# Altium schematic sheet 2_POWER.SchDoc(2_POWER)
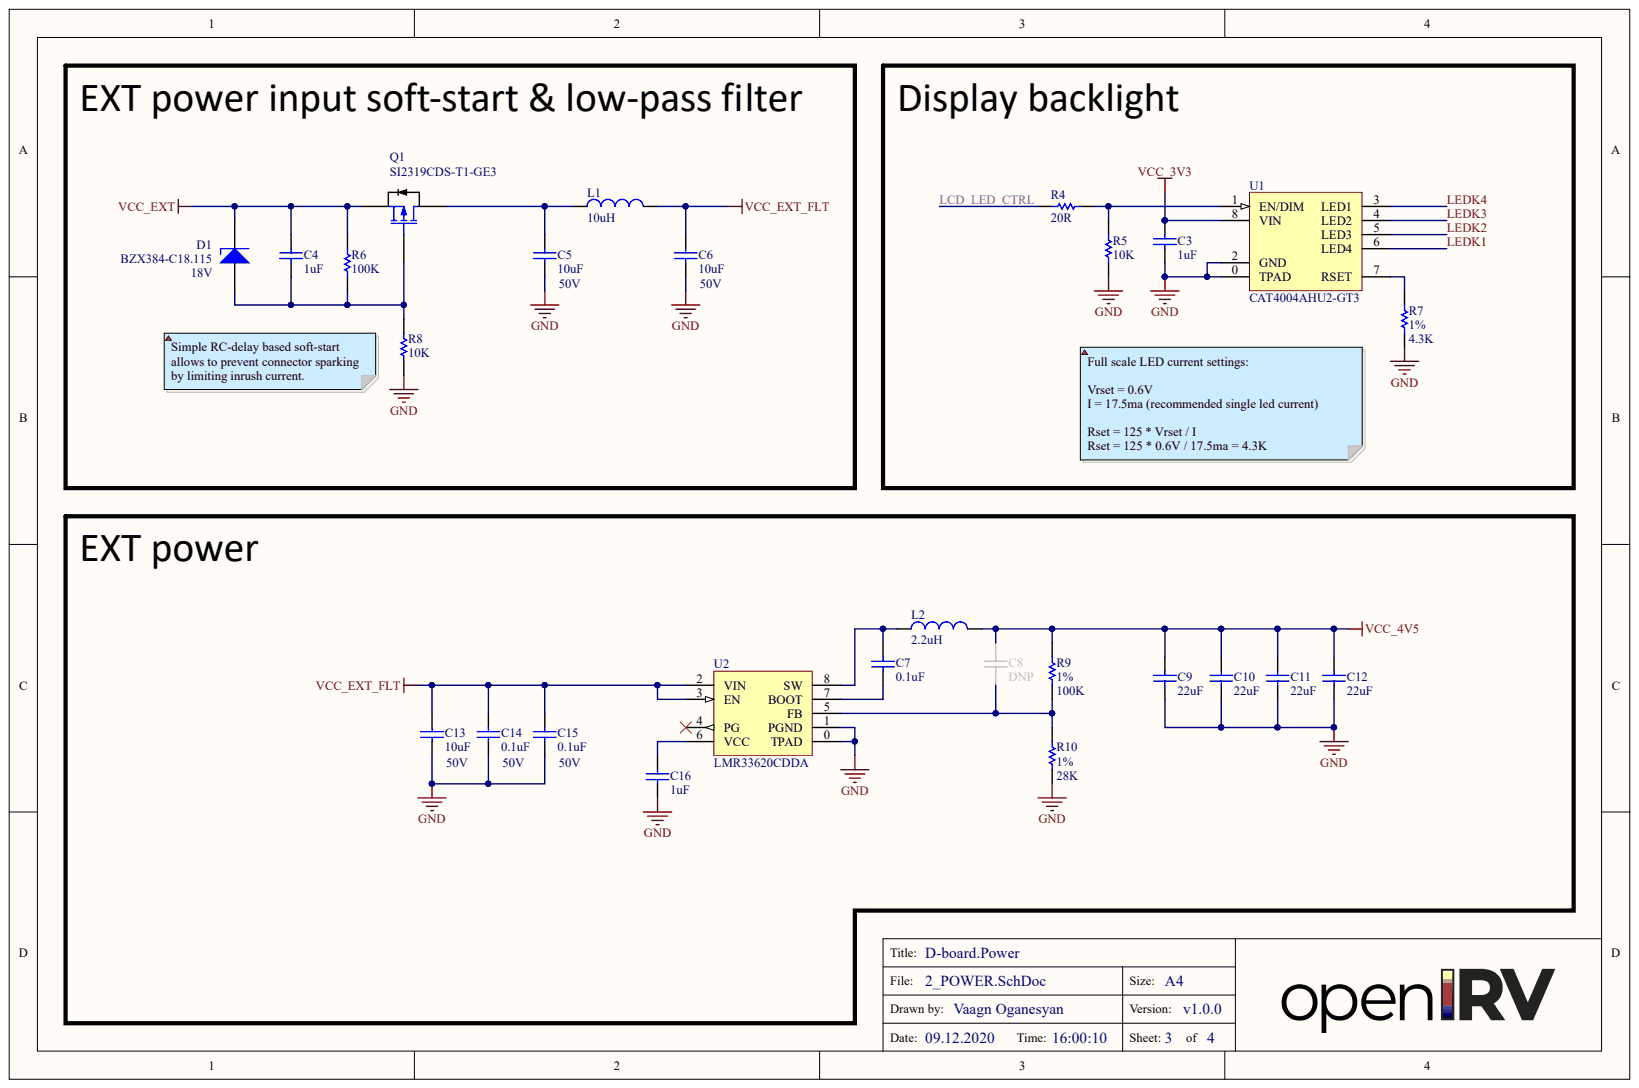
[diagram p0: 2_POWER.SchDoc]

## Parts
C3  1uF  [C0603[1608]]
C4  1uF  [C0603[1608]]
C5  10uF  Voltage: 50V  [C1206[3216]]
C6  10uF  Voltage: 50V  [C1206[3216]]
C7  0.1uF  [C0402[1005]]
C8  DNP  [C0603[1608]]
C9  22uF  [C1206[3216]]
C10  22uF  [C1206[3216]]
C11  22uF  [C1206[3216]]
C12  22uF  [C1206[3216]]
C13  10uF  Voltage: 50V  [C1206[3216]]
C14  0.1uF  Voltage: 50V  [C0402[1005]]
C15  0.1uF  Voltage: 50V  [C0402[1005]]
C16  1uF  [C0603[1608]]
D1  BZX384-C18.115  stab_voltage: 18V  [BZX384]
L1  value 10uH  [CDRH6D28NP-100NC]  (hidden comment: CDRH6D28NP-100NC)
L2  value 2.2uH  [ETQP3MXXXKVP]  (hidden comment: ETQP3M2R2KVP)
Q1  SI2319CDS-T1-GE3  [SI2319CDS-T1-GE3]
R4  20R  [R0402[1005]]
R5  10K  [R0402[1005]]
R6  100K  [R0402[1005]]
R7  4.3K  Tolerance: 1%  [R0603[1608]]
R8  10K  [R0402[1005]]
R9  100K  Tolerance: 1%  [R0603[1608]]
R10  28K  Tolerance: 1%  [R0603[1608]]
U1  CAT4004AHU2-GT3  [CAT4004AHU2-GT3]
U2  LMR33620CDDA  [LMR33620CDDA]

## Nets
GND: C3.1 C5.1 C6.1 C9.1 C10.1 C11.1 C12.1 C13.1 C14.1 C15.1 C16.1 R5.1 R7.1 R8.2 R10.2 U1.0(TPAD) U1.2(GND) U2.0(TPAD) U2.1(PGND)
VCC_3V3: C3.2 U1.8(VIN)
VCC_4V5: C8.2 C9.2 C10.2 C11.2 C12.2 L2.2 R9.1
VCC_EXT: C4.2 D1.2 Q1.2 R6.1
VCC_EXT_FLT: C6.2 C13.2 C14.2 C15.2 L1.1 U2.2(VIN) U2.3(EN)
LCD_LED_CTRL: R4.1
LEDK1: U1.6(LED4)
LEDK2: U1.5(LED3)
LEDK3: U1.4(LED2)
LEDK4: U1.3(LED1)
NetC4_1: C4.1 D1.1 Q1.1 R6.2 R8.1
NetC5_2: C5.2 L1.2 Q1.3
NetC7_1: C7.1 U2.7(BOOT)
NetC7_2: C7.2 L2.1 U2.8(SW)
NetC8_1: C8.1 R9.2 R10.1 U2.5(FB)
NetC16_2: C16.2 U2.6(VCC)
NetR4_2: R4.2 R5.2 U1.1(EN/DIM)
NetR7_2: R7.2 U1.7(RSET)

Not connected: U2 4(PG)

## Library data of the parts
C3 C4 C16 = CAP: Dielectric: X7R; Tolerance: 10%; Voltage: 25V; Component Kind: Standard; Library Name: general.IntLib; Mounting: SMD; Value: 1uF
C5 C6 C13-C15 = CAP: Dielectric: X7R; Tolerance: 10%; Component Kind: Standard; Library Name: general.IntLib; Mounting: SMD
  C5 C6 C13: Value: 10uF
  C14 C15: Value: 0.1uF
C7 = CAP: Dielectric: X7R; Tolerance: 10%; Voltage: 50V; Component Kind: Standard; Library Name: general.IntLib; Mounting: SMD; Value: 0.1uF
C8 = CAP: Component Kind: Standard; Library Name: general.IntLib; Mounting: SMD; Value: DNP
C9-C12 = CAP: Dielectric: X7R; Tolerance: 10%; Voltage: 10V; Component Kind: Standard; Library Name: general.IntLib; Mounting: SMD; Value: 22uF
D1 = Diode_3: Zener diode; Component Kind: Standard; Library Name: general.IntLib; ManufacturerPartNumber: BZX384-C18.115
L1 L2 = Inductor: Component Kind: Standard; Library Name: general.IntLib
  L1: ManufacturerPartNumber: CDRH6D28NP-100NC
  L2: ManufacturerPartNumber: ETQP3M2R2KVP
R4-R6 R8 = RES: Power: 0.06W; Tolerance: 5%; Component Kind: Standard; Library Name: general.IntLib; Mounting: SMD
  R4: Value: 20R
  R5 R8: Value: 10K
  R6: Value: 100K
R7 R9 R10 = RES: Power: 0.1W; Component Kind: Standard; Library Name: general.IntLib; Mounting: SMD
  R7: Value: 4.3K
  R9: Value: 100K
  R10: Value: 28K
U2 = LMR33620CDDA: DC-DC Synchronous Step-Down converter 2A; Component Kind: Standard; Library Name: general.IntLib
Q1 = SI2319CDS-T1-GE3: Component Kind: Standard; Library Name: general.IntLib
U1 = CAT4004AHU2-GT3: Component Kind: Standard; Description: CAT4004AHU2-GT3; Library Name: general.IntLib
